# Altium schematic sheet 50-pin LCD Schematic.SchDoc("50-pin LCD Schematic")
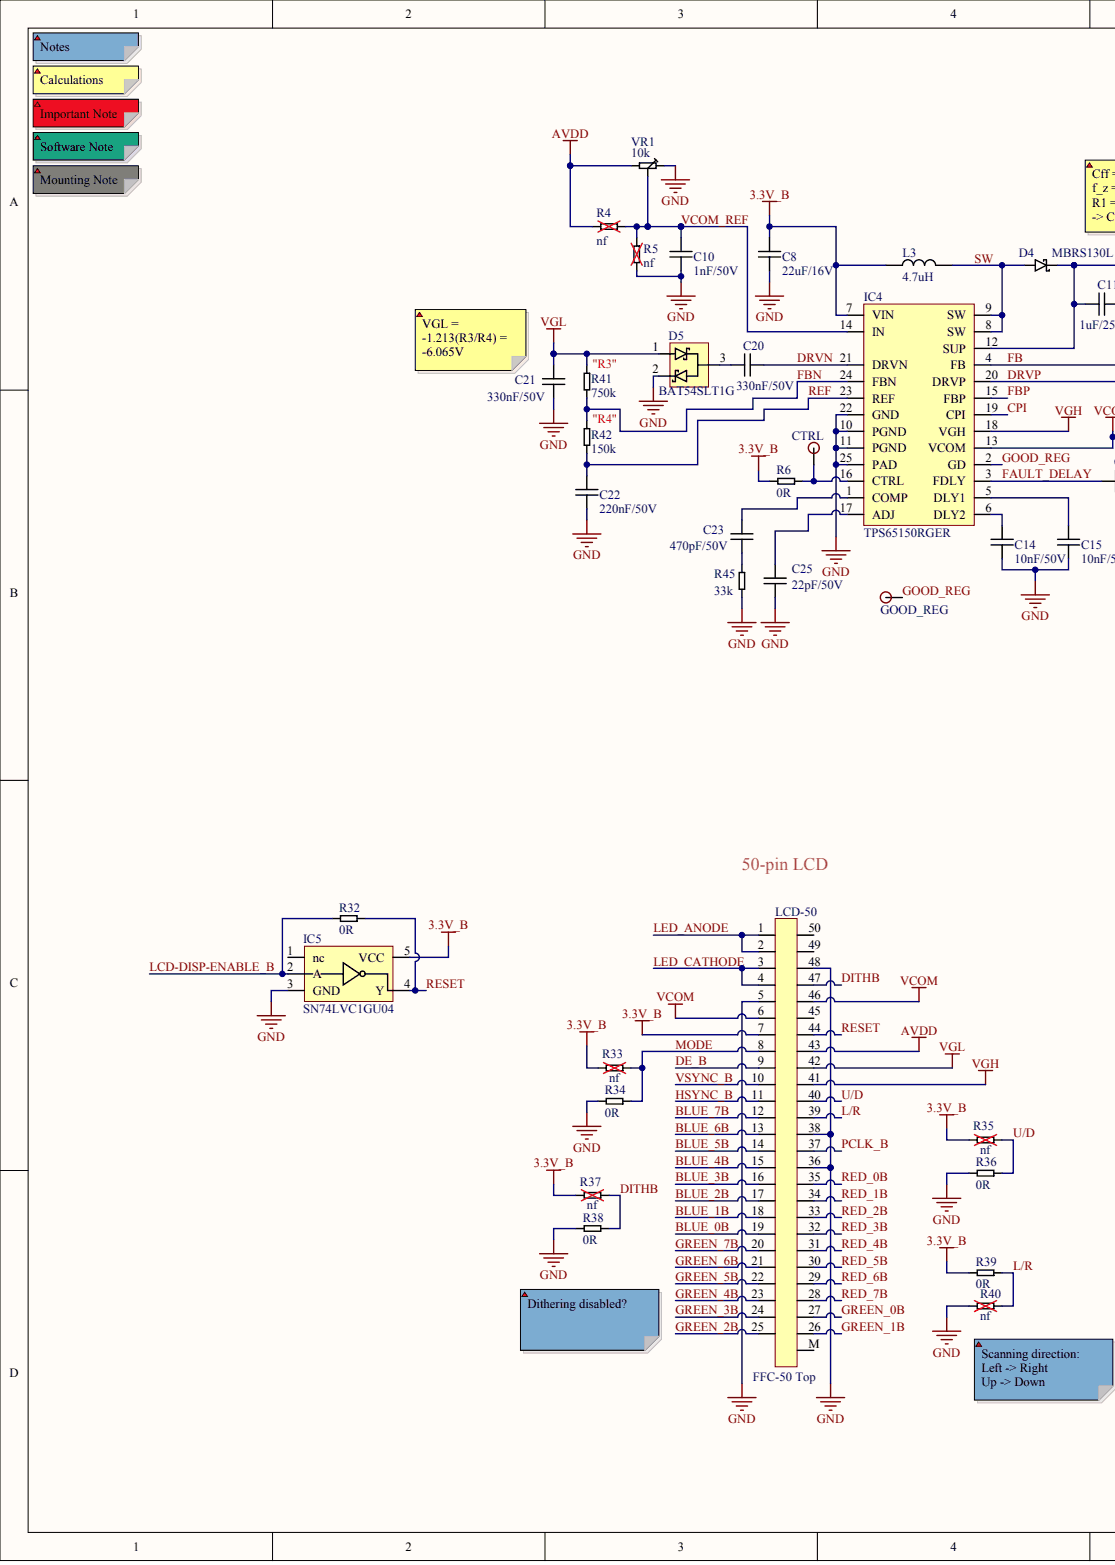
[diagram p0: 50-pin LCD Schematic.SchDoc, left]
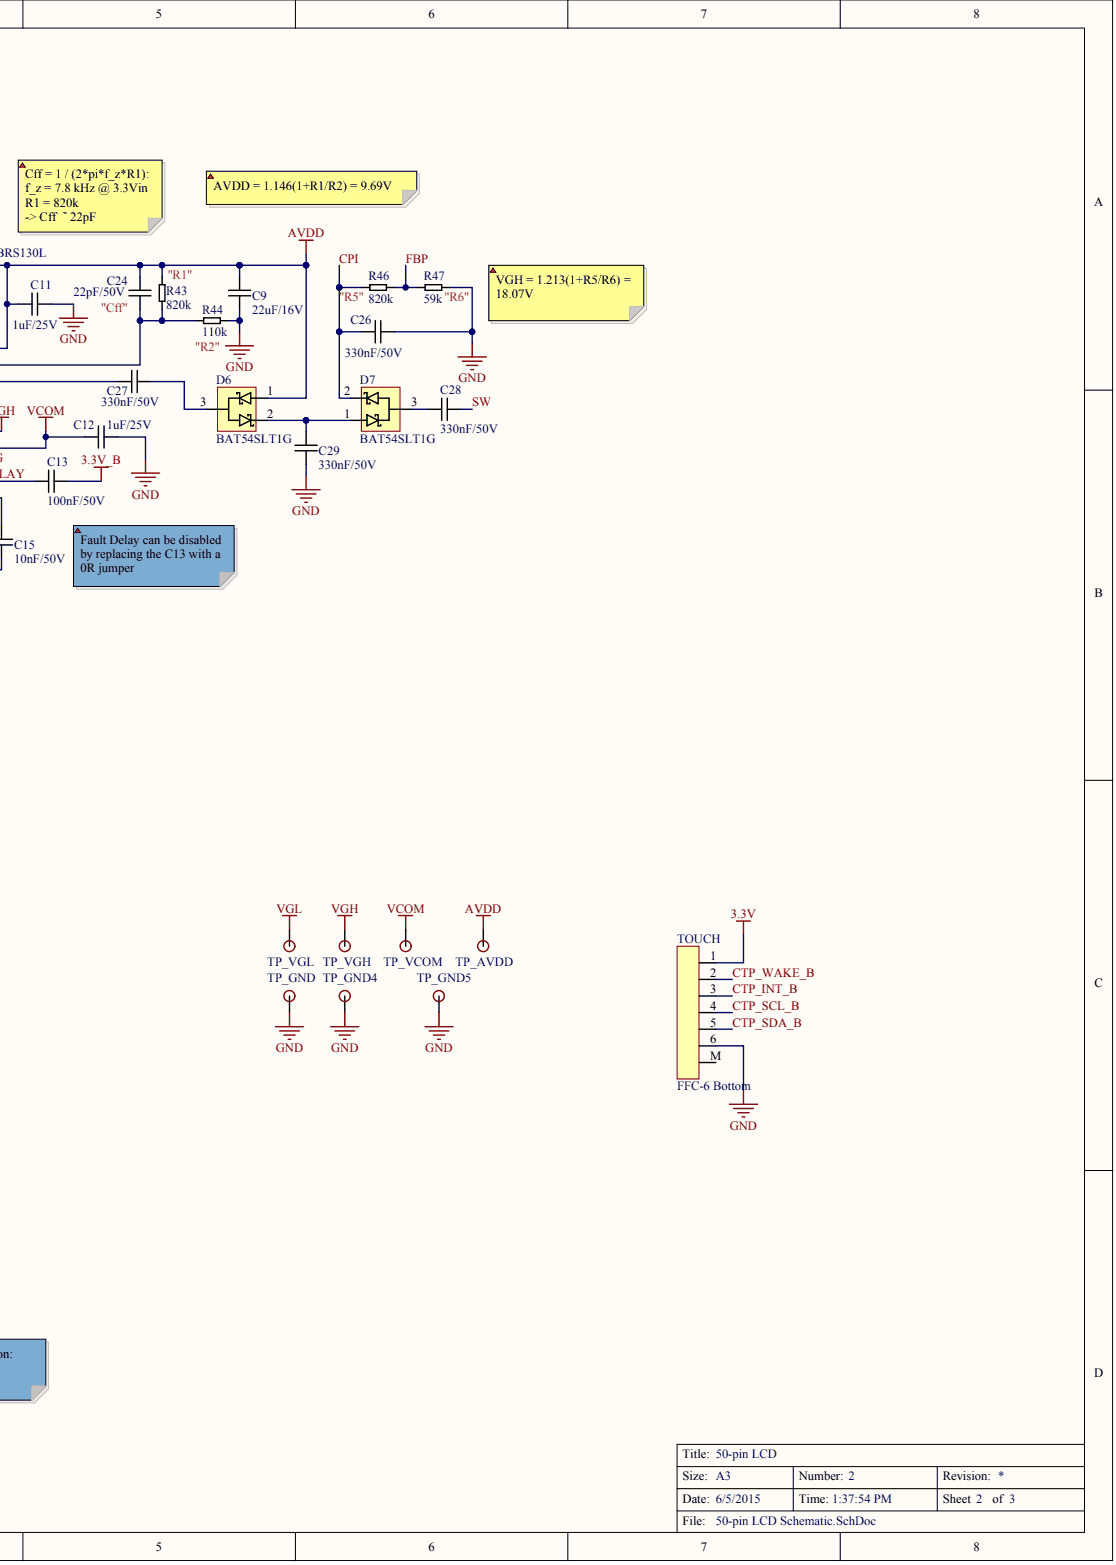
[diagram p1: 50-pin LCD Schematic.SchDoc, right]

## Parts
C8  value 22uF/16V  [0805]  (hidden comment: Cap_0805_22uF/16V)
C9  value 22uF/16V  [0805]  (hidden comment: Cap_0805_22uF/16V)
C10  value 1nF/50V  [0603]  (hidden comment: Cap_0603_1nF/50V)
C11  value 1uF/25V  [0603]  (hidden comment: Cap_0603_1uF/25V)
C12  value 1uF/25V  [0603]  (hidden comment: Cap_0603_1uF/25V)
C13  value 100nF/50V  [0603]  (hidden comment: Cap_0603_100nF/50V)
C14  value 10nF/50V  [0603]  (hidden comment: Cap_0603_10nF/50V)
C15  value 10nF/50V  [0603]  (hidden comment: Cap_0603_10nF/50V)
C20  value 330nF/50V  [0603]  (hidden comment: Cap_0603_330nF/50V)
C21  value 330nF/50V  [0603]  (hidden comment: Cap_0603_330nF/50V)
C22  value 220nF/50V  [0603]  (hidden comment: Cap_0603_220nF/50V)
C23  value 470pF/50V  [0603]  (hidden comment: Cap_0603_470pF/50V)
C24  value 22pF/50V  [0603]  (hidden comment: Cap_0603_22pF/50V)
C25  value 22pF/50V  [0603]  (hidden comment: Cap_0603_22pF/50V)
C26  value 330nF/50V  [0603]  (hidden comment: Cap_0603_330nF/50V)
C27  value 330nF/50V  [0603]  (hidden comment: Cap_0603_330nF/50V)
C28  value 330nF/50V  [0603]  (hidden comment: Cap_0603_330nF/50V)
C29  value 330nF/50V  [0603]  (hidden comment: Cap_0603_330nF/50V)
CTRL  Testpad  (value not drawn)
D4  MBRS130L
D5  BAT54SLT1G
D6  BAT54SLT1G
D7  BAT54SLT1G
GOOD_REG  Testpad  (value not drawn)
IC4  TPS65150RGER  [QFN-24]
IC5  SN74LVC1GU04  [SOT-23-5]
L3  value 4.7uH  [SMD SRN4028 4.8x4.8mm]  (hidden comment: Inductor_SRR4028_4.7uH)
LCD-50  value FFC-50 Top  (hidden comment: FFC 50 pin 0.5mm Top)
R4  value nf  [0603]  (hidden comment: Res_0603_nf)
R5  value nf  [0603]  (hidden comment: Res_0603_nf)
R6  value 0R  [0603]  (hidden comment: Res_0603_0R)
R32  value 0R  [0603]  (hidden comment: Res_0603_0R)
R33  value nf  [0603]  (hidden comment: Res_0603_nf)
R34  value 0R  [0603]  (hidden comment: Res_0603_0R)
R35  value nf  [0603]  (hidden comment: Res_0603_nf)
R36  value 0R  [0603]  (hidden comment: Res_0603_0R)
R37  value nf  [0603]  (hidden comment: Res_0603_nf)
R38  value 0R  [0603]  (hidden comment: Res_0603_0R)
R39  value 0R  [0603]  (hidden comment: Res_0603_0R)
R40  value nf  [0603]  (hidden comment: Res_0603_nf)
R41  value 750k  [0603]  (hidden comment: Res_0603_750k)
R42  value 150k  [0603]  (hidden comment: Res_0603_150k)
R43  value 820k  [0603]  (hidden comment: Res_0603_820k)
R44  value 110k  [0603]  (hidden comment: Res_0603_110k)
R45  value 33k  [0603]  (hidden comment: Res_0603_33k)
R46  value 820k  [0603]  (hidden comment: Res_0603_820k)
R47  value 59k  [0603]  (hidden comment: Res_0603_59k)
TOUCH  value FFC-6 Bottom  (hidden comment: FFC 6 pin 0.5mm Bottom)
TP_AVDD  Testpad  (value not drawn)
TP_GND  Testpad  (value not drawn)
TP_GND4  Testpad  (value not drawn)
TP_GND5  Testpad  (value not drawn)
TP_VCOM  Testpad  (value not drawn)
TP_VGH  Testpad  (value not drawn)
TP_VGL  Testpad  (value not drawn)
VR1  value 10k  [SMD]  (hidden comment: Trimmer_10k)

## Nets
3.3V: TOUCH.1
3.3V_B: C8.2 C13.1 IC4.7(VIN) IC5.5(VCC) L3.1 LCD-50.7 R6.1 R33.1 R35.1 R37.1 R39.1
AVDD: C9.2 C11.2 C24.2 D4.1 D6.1 IC4.12(SUP) LCD-50.43 R4.1 R43.2 TP_AVDD.1 VR1.3
GND: C8.1 C9.1 C10.1 C11.1 C12.2 C14.1 C15.1 C21.1 C22.1 C25.1 C26.1 C29.1 D5.2 IC4.10(PGND) IC4.11(PGND) IC4.22(GND) IC4.25(PAD) IC5.3(GND) LCD-50.5 LCD-50.36 LCD-50.38 LCD-50.48 R5.1 R34.1 R36.1 R38.1 R40.1 R44.1 R45.1 R47.2 TOUCH.6 TP_GND.1 TP_GND4.1 TP_GND5.1 VR1.1
VCOM: C12.1 IC4.13(VCOM) LCD-50.6 LCD-50.46 TP_VCOM.1
VGH: IC4.18(VGH) LCD-50.41 TP_VGH.1
VGL: C21.2 D5.1 LCD-50.42 R41.2 TP_VGL.1
BLUE_0B: LCD-50.19
BLUE_1B: LCD-50.18
BLUE_2B: LCD-50.17
BLUE_3B: LCD-50.16
BLUE_4B: LCD-50.15
BLUE_5B: LCD-50.14
BLUE_6B: LCD-50.13
BLUE_7B: LCD-50.12
CPI: C26.2 D7.2 IC4.19(CPI) R46.2
CTP_INT_B: TOUCH.3
CTP_SCL_B: TOUCH.4
CTP_SDA_B: TOUCH.5
CTP_WAKE_B: TOUCH.2
DE_B: LCD-50.9
DITHB: LCD-50.47 R37.2 R38.2
DRVN: C20.2 IC4.21(DRVN)
DRVP: C27.1 IC4.20(DRVP)
FAULT_DELAY: C13.2 IC4.3(FDLY)
FB: C24.1 IC4.4(FB) R43.1 R44.2
FBN: IC4.24(FBN) R41.1 R42.2
FBP: IC4.15(FBP) R46.1 R47.1
GOOD_REG: GOOD_REG.1 IC4.2(GD)
GREEN_0B: LCD-50.27
GREEN_1B: LCD-50.26
GREEN_2B: LCD-50.25
GREEN_3B: LCD-50.24
GREEN_4B: LCD-50.23
GREEN_5B: LCD-50.22
GREEN_6B: LCD-50.21
GREEN_7B: LCD-50.20
HSYNC_B: LCD-50.11
L/R: LCD-50.39 R39.2 R40.2
LCD-DISP-ENABLE_B: IC5.2(A) R32.1
LED_ANODE: LCD-50.1 LCD-50.2
LED_CATHODE: LCD-50.3 LCD-50.4
MODE: LCD-50.8 R33.2 R34.2
PCLK_B: LCD-50.37
RED_0B: LCD-50.35
RED_1B: LCD-50.34
RED_2B: LCD-50.33
RED_3B: LCD-50.32
RED_4B: LCD-50.31
RED_5B: LCD-50.30
RED_6B: LCD-50.29
RED_7B: LCD-50.28
REF: C22.2 IC4.23(REF) R42.1
RESET: IC5.4(Y) LCD-50.44 R32.2
SW: C28.1 D4.2 IC4.8(SW) IC4.9(SW) L3.2
U/D: LCD-50.40 R35.2 R36.2
VCOM_REF: C10.2 IC4.14(IN) R4.2 R5.2 VR1.2
VSYNC_B: LCD-50.10
NetC14_2: C14.2 IC4.6(DLY2)
NetC15_2: C15.2 IC4.5(DLY1)
NetC20_1: C20.1 D5.3
NetC23_1: C23.1 R45.2
NetC23_2: C23.2 IC4.1(COMP)
NetC25_2: C25.2 IC4.17(ADJ)
NetC27_2: C27.2 D6.3
NetC28_2: C28.2 D7.3
NetC29_2: C29.2 D6.2 D7.1
NetCTRL_1: CTRL.1 IC4.16(CTRL) R6.2

Not connected: IC5 1(nc); LCD-50 45 49 50 M; TOUCH M

## Library data of the parts
C8 C9 = (no symbol name): Part No.: C2012X5R1C226M125AC; Manufacturer: Murata; DigiKey: 445-7647-1-ND; Price SEK (No VAT): 6; Mouser: 810-C2012X5R1C226M
C10 = (no symbol name): Part No.: C1608X7R1H102K080AE; Manufacturer: TDK; Mouser: 810-C1608X7R1H102KAE; DigiKey: 445-15923-1-ND; Price SEK (No VAT): 0,4
C11 C12 = (no symbol name): Part No.: C1608X5R1E105K080AC; Manufacturer: TDK; DigiKey: 445-5146-1-ND; Mouser: 810-C1608X5R1E105K; Farnell: 2346891; Price SEK (No VAT): 1
C13 = (no symbol name): Part No.: C1608X7R1H104K080AA; Manufacturer: TDK; RS: 741-4459 (500-pack); DigiKey: 445-1314-1-ND; Mouser: 810-C1608X7R1H104K; Price SEK (No VAT): 0,2
C14 C15 = (no symbol name): Part No.: C1608X7R1H103K080AA; Manufacturer: TDK; RS: 788-2981 (50-pack); DigiKey: 445-1311-1-ND; Mouser: 810-C1608X7R1H103K; Price SEK (No VAT): 0,3; Farnell: 1907315 (50-pack)
C20 C21 C26-C29 = (no symbol name): Part No.: C1608X7R1H334K080AC; Manufacturer: TDK; RS: 788-2991 (50-pack); DigiKey: 445-5950-1-ND; Mouser: 810-C1608X7R1H334K; Price SEK (No VAT): 0,8; Farnell: 2346907
C22 = (no symbol name): Part No.: C1608X7R1H224K080AB; Manufacturer: TDK; RS: 788-2994 (50-pack); DigiKey: 445-7408-1-ND; Mouser: 810-C1608X7R1H224K; Price SEK (No VAT): 0,5
C23 = (no symbol name): Part No.: GRM188R71H471KA01D; Manufacturer: Murata; RS: 723-5714 (200-pack); DigiKey: 490-1489-1-ND; Mouser: 81-GRM39X471K50D; Price SEK (No VAT): 0,5; Farnell: *
C24 C25 = (no symbol name): Part No.: C1608C0G1H220J080AA; Manufacturer: TDK; RS: 788-2947 (50-pack); DigiKey: 445-1273-1-ND; Mouser: 445-1273-1-ND; Price SEK (No VAT): 0,3; Farnell: 1907288 (50-pack)
D4 = (no symbol name): Manufacturer: Fairchild; Part No.: MBRS130L; Mouser: 512-MBRS130L; Price SEK (No VAT): 3; DigiKey: MBRS130LFSCT-ND; Value: MBRS130L
D5-D7 = (no symbol name): Manufacturer: ON Semiconductor; Part No.: BAT54SLT1G; Mouser: 863-BAT54SLT1G (Alt: BAT54S-G3-08); Price SEK (No VAT): 2; DigiKey: BAT54SLT1GOSCT-ND; Value: BAT54SLT1G
IC4 = (no symbol name): Manufacturer: TI; DigiKey: 296-21167-1-ND; Part No.: TPS65150RGER; Price SEK (No VAT): 25; Mouser: 595-TPS65150RGER; RS: *; Value: TPS65150RGER
IC5 = (no symbol name): Part No.: SN74LVC1GU04DBVR; Manufacturer: TI; DigiKey: 296-8487-1-ND; Price SEK (No VAT): 3; Mouser: 595-SN74LVC1GU04DBVR; Value: SN74LVC1GU04
L3 = (no symbol name): Part No.: SRR4028-4R7Y; Manufacturer: Bourns; Mouser: 652-SRR4028-4R7Y; Price SEK (No VAT): 6; DigiKey: SRR4028-4R7YCT-ND; RS: *; Farnell: *
LCD-50 = (no symbol name): Part No.: 54104-5031; Manufacturer: Molex; RS: 670-2597 (10-pack); Mouser: 538-54104-5031; DigiKey: WM3952CT-ND; Farnell: 2063792
R6 R32 R34 R36 R38 R39 = (no symbol name): Part No.: CRCW06030000Z0EA; Manufacturer: Vishay; Price SEK (No VAT): 0,1; DigiKey: 541-0.0GCT-ND; Mouser: 71-CRCW0603-0-E3
R41 = (no symbol name): Part No.: CRCW0603750KFKEA; Manufacturer: Vishay; Price (No VAT): 0.1; DigiKey: 541-750KHCT-ND; Mouser: 71-CRCW0603-750K-E3
R42 = (no symbol name): Part No.: CRCW0603150KFKEA; Manufacturer: Vishay; Price (No VAT): 0.1; DigiKey: 541-150KHCT-ND; Mouser: 71-CRCW0603-150K-E3
R43 R46 = (no symbol name): Part No.: CRCW0603820KFKEA; Manufacturer: Vishay; Price (No VAT): 0.1; DigiKey: 541-820KHCT-ND; Mouser: 71-CRCW0603-820K-E3
R44 = (no symbol name): Part No.: CRCW0603110KFKEA; Manufacturer: Vishay; Price (No VAT): 0.1; DigiKey: 541-110KHCT-ND; Mouser: 71-CRCW0603-110K-E3
R45 = (no symbol name): Part No.: CRCW060333K0FKEA; Manufacturer: Vishay; Price SEK (No VAT): 0,1; DigiKey: 541-33.0KHCT-ND; Mouser: 71-CRCW0603-33K-E3
R47 = (no symbol name): Part No.: CRCW060359K0FKEA; Manufacturer: Vishay; Price SEK (No VAT): 0,1; Mouser: 71-CRCW0603-59K-E3; DigiKey: 541-59.0KHCT-ND
TOUCH = (no symbol name): Part No.: 52746-0671; Manufacturer: Molex; RS: 702-5431 (10-pack); Mouser: 538-52746-0671; DigiKey: WM7977CT-ND; Farnell: *
VR1 = (no symbol name): Part No.: PVG3A103C01R00; Manufacturer: Murata; RS: 725-0031; Mouser: 81-PVG3A103C01R00; DigiKey: 490-2644-1-ND; Price (No VAT): 10,67
